# Altium schematic sheet XYAmpHammondFrontPnlRev1b.SchDoc(XYAmpHammondFrontPnlRev1b)
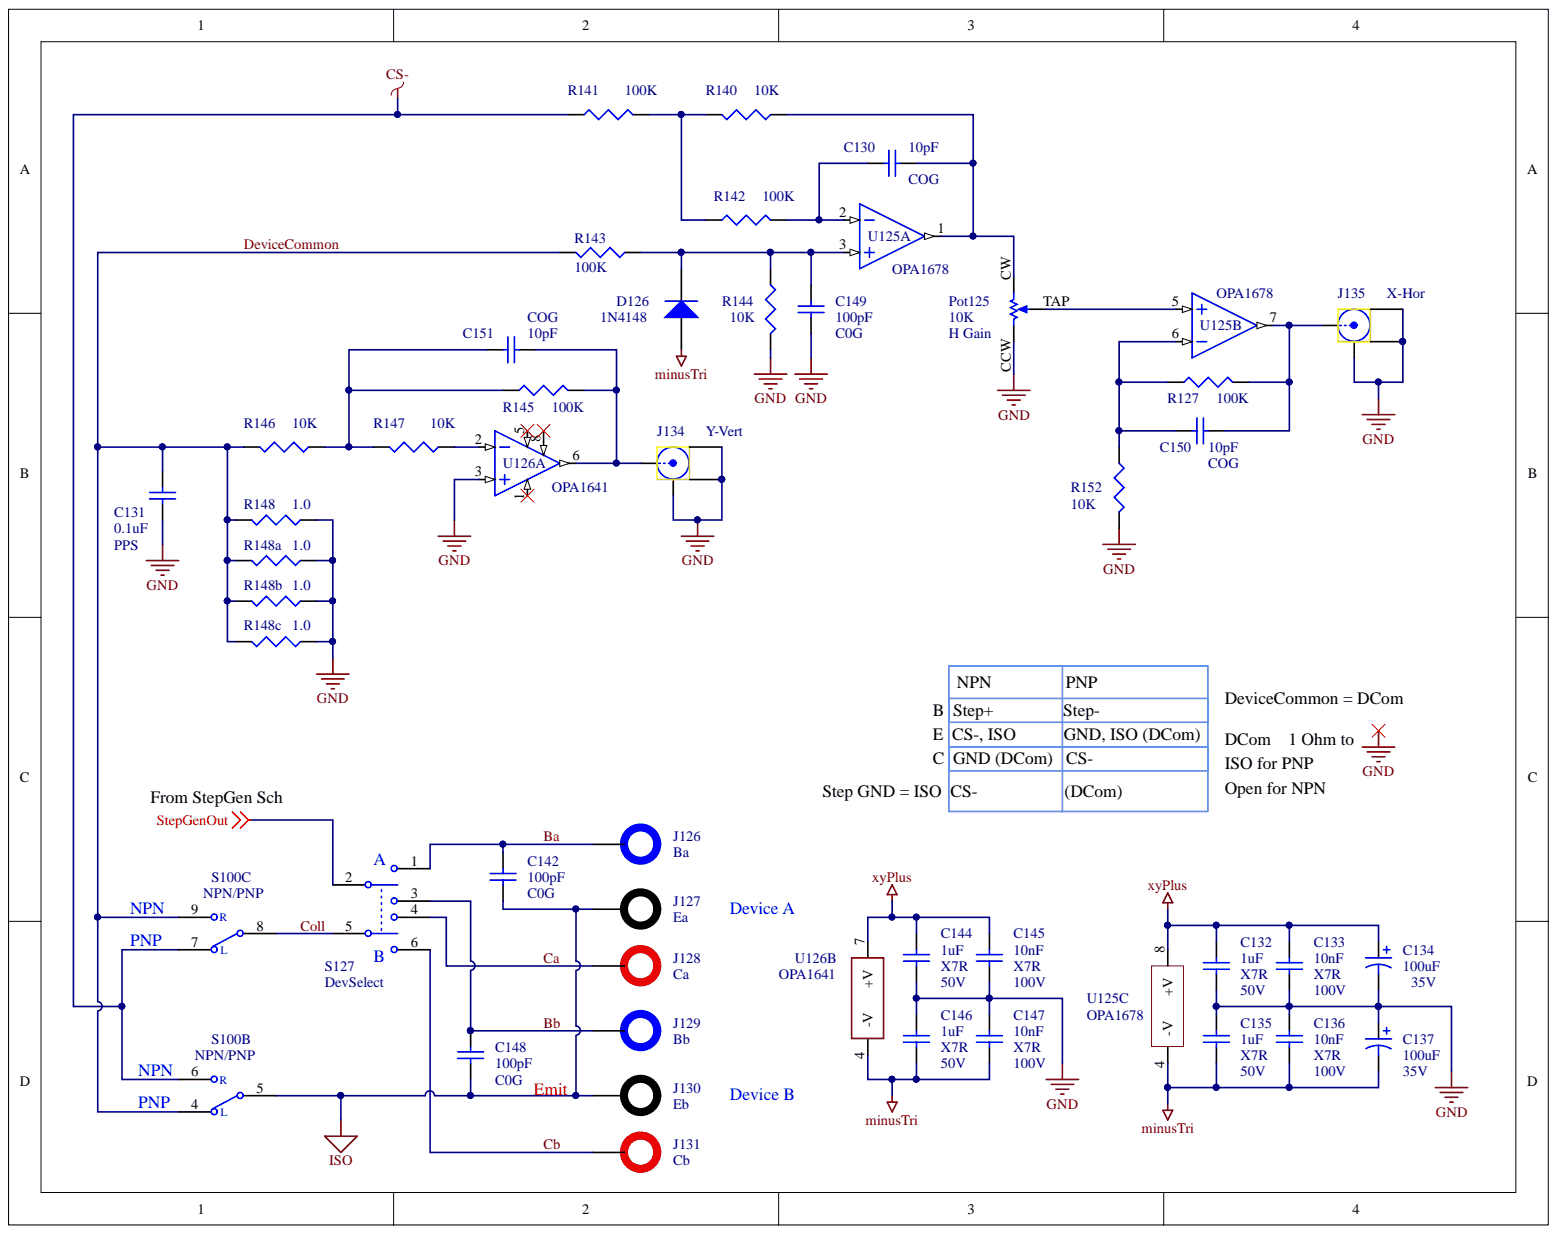
[diagram p0: XYAmpHammondFrontPnlRev1b.SchDoc]

## Parts
C130  value 10pF  Temperature Coefficient: COG  [CAPC3216M]  (hidden comment: Cap)
C131  value 0.1uF  Dielectric: PPS  [CAPC3225M]  (hidden comment: Cap)
C132  1uF  Voltage Rating (DC): 50V  Dielectric: X7R  [CAPC3216M]
C133  10nF  Voltage Rating (DC): 100V  Dielectric: X7R  [CAPC3216M]
C134  100uF  Voltage Rating: 35V  [CAPPR2.5-6.3X10]
C135  1uF  Voltage Rating (DC): 50V  Dielectric: X7R  [CAPC3216M]
C136  10nF  Voltage Rating (DC): 100V  Dielectric: X7R  [CAPC3216M]
C137  100uF  Voltage Rating: 35V  [CAPPR2.5-6.3X10]
C142  value 100pF  Temperature Coefficient: C0G  [CAPC3216M]  (hidden comment: Cap)
C144  1uF  Voltage Rating (DC): 50V  [CAPC3216M]
C145  10nF  Voltage Rating (DC): 100V  Dielectric: X7R  [CAPC3216M]
C146  1uF  Voltage Rating (DC): 50V  [CAPC3216M]
C147  10nF  Voltage Rating (DC): 100V  Dielectric: X7R  [CAPC3216M]
C148  value 100pF  Temperature Coefficient: C0G  [CAPC3216M]  (hidden comment: Cap)
C149  value 100pF  Temperature Coefficient: C0G  [CAPC3216M]  (hidden comment: Cap)
C150  value 10pF  Temperature Coefficient: COG  [CAPC3216M]  (hidden comment: Cap)
C151  value 10pF  Temperature Coefficient: COG  [CAPC3216M]  (hidden comment: Cap)
D126  1N4148  [SOD123]
J126  Ba  [Banana-Turet]
J127  Ea  [Banana-Turet]
J128  Ca  [Banana-Turet]
J129  Bb  [Banana-Turet]
J130  Eb  [Banana-Turet]
J131  Cb  [Banana-Turet]
J134  Y-Vert  [BNC-Vert]
J135  X-Hor  [BNC-Vert]
Pot125  H Gain  value 10K  [Off-PCB]
R127  100k  [RESC3216M]
R140  10K  [RESC3216M]
R141  100k  [RESC3216M]
R142  100k  [RESC3216M]
R143  100k  [RESC3216M]
R144  10K  [RESC3216M]
R145  100k  [RESC3216M]
R146  10K  [RESC3216M]
R147  10K  [RESC3216M]
R148  1  value 1.0  [RESC6432BM]
R148a  1  value 1.0  [RESC6432BM]
R148b  1  value 1.0  [RESC6432BM]
R148c  1  value 1.0  [RESC6432BM]
R152  10K  [RESC3216M]
S100  NPN/PNP  [EG2424]  (parts B,C)
S127  DevSelect  [EG2413]
U125  OPA1678  [SOIC127P600X168_HS-8N]  (parts A,B,C)
U126  OPA1641  [SOIC127P600X168_HS-8N]  (parts A,B)

## Nets
CS-: R141.1 S100.6(R) S100.7(L)
GND: C131.2 C132.2 C133.1 C134.2 C135.1 C136.2 C137.1 C144.2 C145.1 C146.1 C147.2 C149.1 J134.2 J134.MH0 J134.MH1 J135.2 J135.MH0 J135.MH1 Pot125.CCW R144.1 R148.1 R148a.1 R148b.1 R148c.1 R152.1 U126.3
ISO: C142.1 C148.1 J127.1 J130.1 S100.5
minusTri: C135.2 C136.1 C137.2 C146.2 C147.1 D126.1 U125.4(-V) U126.4(-V)
StepGenOut: S127.2
xyPlus: C132.1 C133.2 C134.1 C144.1 C145.2 U125.8(+V) U126.7(+V)
Ba: C142.2 J126.1 S127.1
Bb: C148.2 J129.1 S127.3
Ca: J128.1 S127.4
Cb: J131.1 S127.6(B)
Coll: S100.8 S127.5
DeviceCommon: C131.1 R143.2 R146.1 R148.2 R148a.2 R148b.2 R148c.2 S100.4(L) S100.9(R)
NetC130_1: C130.1 R142.2 U125.2
NetC130_2: C130.2 Pot125.CW R140.2 U125.1
NetC149_2: C149.2 D126.2 R143.1 R144.2 U125.3
NetC150_1: C150.1 R127.1 R152.2 U125.6
NetC150_2: C150.2 J135.1 R127.2 U125.7
NetC151_1: C151.1 R145.1 R146.2 R147.1
NetC151_2: C151.2 J134.1 R145.2 U126.6
NetPot125_TAP: Pot125.TAP U125.5
NetR140_1: R140.1 R141.2 R142.1
NetR147_2: R147.2 U126.2

Not connected: U126 1 5 8

## Library data of the parts
C130 C150 C151 = Cap: CAP CER 10PF 100V NP0 1206; Manufacturer Part Number: C1206C100J1GACTU; Manufacturer: Kemet; Tolerance: ±5%; Voltage - Rated: 100V; Thickness (Max): 0.035" (0.88mm); Supplier Part Number: 399-8124-1-ND; Supplier: Digi-Key; Size / Dimension: 0.126" L x 0.063" W (3.20mm x 1.60mm); Simulation: CAP; Signal Integrity: Cap; RoHS: RoHS Compliant; Packaging: Cut Tape (CT); Package / Case: 1206 (3216 Metric); Operating Temperature: -55°C ~ 125°C; Mounting Type: Surface Mount, MLCC; Library Name: Miscellaneous Devices.IntLib; Component Kind: Standard; Category: Capacitors; Capacitance: 10pF; Applications: General Purpose
C131 = Cap: CAP FILM 1UF 20% 16VDC 1210; Manufacturer Part Number: ECPU1C105MA5; Manufacturer: Panasonic; Voltage Rating (DC): 16V; Insulation Resistance: 300MR; Dissipation Factor: 1.5%; Width: 2.5mm; Voltage Rating: 16V; Voltage: 16V; Tolerance: 20%; Termination: SMD/SMT; Supplier Part Number: PCF1132CT-ND; Supplier: Digi-Key; Simulation: CAP; Signal Integrity: Cap; REACH SVHC: No SVHC; Radiation Hardening: No; Packaging: Tape and Reel; Package Quantity: 1; Number of Pins: 2; Mount: Surface Mount; Min Operating Temperature: -40°C; Max Operating Temperature: 85°C; Library Name: Miscellaneous Devices.IntLib; Length: 3.2mm; Lead Free: Lead Free; Height - Seated (Max): 0.063inch; Height: 1.4mm; Depth: 2.5mm; Contact Plating: Copper,Tin,Silver; Component Kind: Standard; Case Code (Metric): 3225; Case Code (Imperial): 1210; Case/Package: 1210; Capacitance: 1uF
C132 C135 C144 C146 = Cap: CAP CER 1UF 50V X7R 1206; Manufacturer Part Number: C1206C105K5RACTU; Manufacturer: KEMET; Tolerance: 10%; Dielectric Material: Ceramic; Width: 0.063inch; Voltage Rating: 50V; Voltage: 50V; Value: 1uF; Thickness: 0.043inch; Termination: SMD/SMT; Supplier Part Number: 399-8147-6-ND; Supplier: Digi-Key; Simulation: CAP; Signal Integrity: Cap; Series: C; REACH SVHC: No SVHC; Radiation Hardening: No; Packaging: Tape and Reel; Package Quantity: 1; Number of Pins: 2; Mount: Surface Mount; Min Operating Temperature: -55°C; Max Operating Temperature: 125°C; Library Name: Miscellaneous Devices.IntLib; Length: 3.2mm; Height: 1mm; Depth: 1.6mm; Construction: Flat; Component Kind: Standard; Case Code (Metric): 3216; Case Code (Imperial): 1206; Case/Package: 1206; Capacitance: 1uF
  C144 C146: Dielectric: X7R
C133 C136 C145 C147 = Cap: CAP CER 10NF 100V X7R 1206; Manufacturer Part Number: C1206C103K1RACTU; Manufacturer: KEMET; Tolerance: 10%; Dissipation Factor: 2.5%; Dielectric Material: Ceramic; Width: 0.063inch; Voltage Rating: 100V; Voltage: 100V; Value: 10nF; Thickness: 0.035inch; Termination: SMD/SMT; Supplier Part Number: 399-1236-6-ND; Supplier: Digi-Key; Simulation: CAP; Signal Integrity: Cap; Series: C; REACH SVHC: No SVHC; Radiation Hardening: No; Packaging: Tape and Reel; Package Quantity: 1; Number of Pins: 2; Mount: Surface Mount,PCB; Min Operating Temperature: -55°C; Max Operating Temperature: 125°C; Library Name: Miscellaneous Devices.IntLib; Length: 3.2mm; Height: 0.78mm; Depth: 1.6mm; Contact Plating: Tin,Matte; Construction: Flat; Component Kind: Standard; Case Code (Metric): 3216; Case Code (Imperial): 1206; Case/Package: 1206; Capacitance: 10nF
C134 C137 = Cap Pol1: CAP ALUM 100UF 20% 35V THRU HOLE; Manufacturer Part Number: 35YXJ100M6.3X11; Manufacturer: Rubycon; Ripple Current: 400mA; Polarity: Polar; Life (Hours): 7000Hour; Leakage Current: 3uA; Lead Diameter: 0.5mm; Impedance: 220mR; Voltage: 35V; Value: 100uF; Tolerance: 20%; Termination: Radial; Supplier Part Number: 1189-2260-ND; Supplier: Digi-Key; Simulation: CAP; Signal Integrity: Cap Pol; Radiation Hardening: No; Packaging: Bulk; Package Quantity: 3000; Mount: Through Hole; Min Operating Temperature: -40°C; Max Operating Temperature: 105°C; Library Name: Miscellaneous Devices.IntLib; Lead Pitch: 2.5mm; Height - Seated (Max): 0.492inch; Height: 11mm; Diameter: 6.3mm; Component Kind: Standard; Case/Package: Radial; Capacitance: 100uF
C142 C148 C149 = Cap: CAP CER 100PF 100V NP0 1206; Manufacturer Part Number: C1206C101J1GACTU; Manufacturer: Kemet; Tolerance: ±5%; Voltage - Rated: 100V; Thickness (Max): 0.035" (0.88mm); Supplier Part Number: 399-1206-1-ND; Supplier: Digi-Key; Size / Dimension: 0.126" L x 0.063" W (3.20mm x 1.60mm); Simulation: CAP; Signal Integrity: Cap; RoHS: RoHS Compliant; Packaging: Cut Tape (CT); Package / Case: 1206 (3216 Metric); Operating Temperature: -55°C ~ 125°C; Mounting Type: Surface Mount, MLCC; Library Name: Miscellaneous Devices.IntLib; Features: Low ESL; Component Kind: Standard; Category: Capacitors; Capacitance: 100pF; Applications: General Purpose
D126 = Diode 1N4148: DIODE GP 75V 150MA SOD123FL; Manufacturer Part Number: 1N4148WFL-G3-08; Manufacturer: Vishay Semiconductor Diodes Division; Voltage - Forward (Vf) (Max) @ If: 1.2V @ 100mA; Speed: Small Signal =< 200mA (Io), Any Speed; Reverse Recovery Time (trr): 4ns; Diode Type: Standard; Current - Reverse Leakage @ Vr: 5µA @ 75V; Voltage - DC Reverse (Vr) (Max): 75V; Value: 1N4148; Supplier Part Number: 1N4148WFL-G3-08GICT-ND; Supplier Device Package: SOD-123FL; Supplier: Digi-Key; Simulation: 1N4148; Signal Integrity: 1N4148; RoHS: RoHS Compliant; Published: 8-Jun-2000; Packaging: Cut Tape (CT); PackageReference: DO-35; PackageDescription: Diode, Axial; Body 3.8 x 1.9 mm (LxDia. max), Lead Dia. 0.53 mm (max); Package / Case: SOD-123F; Operating Temperature - Junction: 150°C (Max); Mounting Type: Surface Mount; Library Name: Miscellaneous Devices.IntLib; LatestRevisionNote: Re-released for DXP Platform.; LatestRevisionDate: 17-Jul-2002; DatasheetVersion: 1997; Current - Average Rectified (Io): 150mA; ComponentLink2URL: http://www.fairchildsemi.com/ds/1N%2F1N4148.pdf; ComponentLink2Description: Datasheet; ComponentLink1URL: http://www.fairchildsemi.com/; ComponentLink1Description: Manufacturer Link; Component Kind: Standard; Code_JEDEC: DO-204-AH; Category: Discrete Semiconductor Products; Publisher: Altium Limited
J126 J129 = Banana-BLUE: Conn Banana F 1 POS Solder Lug ST Panel Mount 1 Port; Manufacturer Part Number: 108-0910-001; Manufacturer: Bel Cinch; Wire/Cable Gauge: 12AWG; Voltage Rating (DC): 7kV; Value: Blue; Number of Contacts: 1; Max Current Rating: 15A; Insulation: Mating End Insulated; Gender: Female; Body Material: Nylon,Polyamide,PA66,Brass; Approvals: UL; Voltage Rating: 7kV; Termination: Solder; Supplier Part Number: J155-ND; Supplier: Digi-Key; Series: -; REACH SVHC: No SVHC; Radiation Hardening: No; Packaging: Bulk; Package Quantity: 25; Orientation: Straight; Mount: Panel; Min Operating Temperature: -55°C; Max Operating Temperature: 85°C; Library Name: MY_CONNECTORS.SchLib; Length: 0.75inch; Current Rating: 15A; Contact Plating: Nickel,Tin; Contact Material: Brass; Component Kind: Standard; Color: Blue
J127 J130 = Banana-BLACK: Conn Banana F 1 POS Solder Lug ST Panel Mount 1 Port; Manufacturer Part Number: 108-0903-001; Manufacturer: Bel Cinch; Wire/Cable Gauge: 12AWG; Voltage Rating (DC): 7kV; Value: Black; Number of Contacts: 1; Max Current Rating: 15A; Insulation: Mating End Insulated; Gender: Female; Body Material: Nylon,Polyamide,PA66,Brass; Approvals: UL; Voltage Rating: 7kV; Termination: Solder; Supplier Part Number: J152-ND; Supplier: Digi-Key; Series: -; REACH SVHC: No SVHC; Radiation Hardening: No; Packaging: Bulk; Package Quantity: 25; Orientation: Straight; Mount: Panel; Min Operating Temperature: -55°C; Max Operating Temperature: 85°C; Library Name: MY_CONNECTORS.SchLib; Length: 25.78mm; Insulation Material: Nylon; Current Rating: 15A; Contact Plating: Nickel,Tin; Contact Material: Brass; Component Kind: Standard; Color: Black
J128 J131 = Banana-RED: Conn Banana F 1 POS Solder Lug ST Panel Mount 1 Port; Manufacturer Part Number: 108-0902-001; Manufacturer: Bel Cinch; Wire/Cable Gauge: 12AWG; Voltage Rating (DC): 7kV; Value: Red; Number of Contacts: 1; Max Current Rating: 15A; Insulation: Mating End Insulated; Gender: Female; Body Material: Nylon,Polyamide,PA66,Brass; Approvals: UL; Voltage Rating: 7kV; Termination: Solder; Supplier Part Number: J151-ND; Supplier: Digi-Key; Series: -; REACH SVHC: No SVHC; Radiation Hardening: No; Packaging: Bulk; Package Quantity: 25; Orientation: Straight; Mount: Panel; Min Operating Temperature: -55°C; Max Operating Temperature: 85°C; Library Name: MY_CONNECTORS.SchLib; Length: 25.78mm; Insulation Material: Nylon; Current Rating: 15A; Contact Plating: Tin,Nickel; Contact Material: Brass; Component Kind: Standard; Color: Red
J134 J135 = BNC-Vert: CONN BNC JACK STR 50 OHM PCB; Manufacturer: TE Connectivity; Wire/Cable Type: Coaxial; Value: 1-1634505-0; Tail Length: 0.169inch; Sealable: No (Without); Polarity: Normal; Plating: Nickel; PCB Thickness: 0.126inch; PCB Mount Retention: With; PCB Mounting Orientation: Vertical; Number of Positions: 1; Max Frequency: 4GHz; Insulation Resistance: 5GR; Impedance: 50R; Gender: Female; Dielectric Material: Polyethylene; Connector Type: BNC,Jack; Body Material: Zinc; Termination: Solder; Supplier Part Number: A97558-ND; Supplier: Digi-Key; REACH SVHC: Unknown; Radiation Hardening: No; Packaging: Box; Package Quantity: 100; Orientation: Straight; Mount: Panel; Min Operating Temperature: -55°C; Max Operating Temperature: 85°C; Manufacturer Part Number: 1-1634505-0; Library Name: MY_CONNECTORS.SchLib; Length: 15.8mm; Insulation Material: Polyethylene; Housing Material: Polyester; Housing Color: Black; Frequency: 4GHz; Fastening Type: Bayonet; ELV: Compliant; Depth: 14.76mm; Contact Plating: Gold; Contact Material: Brass; Component Kind: Standard; Color: Black; Body Plating: Nickel
Pot125 = POT-Single: PNL MNT 10K OHM 0.125W; Manufacturer Part Number: RV16AF-10-15R1-B10K-3LA; Manufacturer: Alpha Taiwan; Supplier Part Number: 31JN501-F; Supplier: Mouser; Component Kind: Standard; Library Name: MY_POTS.SchLib
R127 R141-R143 R145 = Res3: RES 100K OHM 1% 1/4W 1206; Manufacturer Part Number: RMCF1206FT100K; Manufacturer: Stackpole Electronics; Temperature Coefficient: 100ppm/°C; Resistance: 100kR; Power Rating: 250mW; Width: 0.063inch; Value: 100K; Tolerance: 1%; Supplier Part Number: RMCF1206FT100KCT-ND; Supplier: Digi-Key; Simulation: RESISTOR; Signal Integrity: Res; Radiation Hardening: No; Packaging: Tape and Reel; Number of Terminations: 2; Mount: Surface Mount; Min Operating Temperature: -55°C; Military Standard: Not; Max Power Dissipation: 250mW; Max Operating Temperature: 155°C; Library Name: Miscellaneous Devices.IntLib; Length: 0.126inch; Lead Free: Lead Free; Height: 0.028inch; Features: Automotive AEC-Q200; Depth: 1.6mm; Contact Plating: Matte,Tin; Composition: Thick Film; Component Kind: Standard; Case Code (Metric): 3216; Case Code (Imperial): 1206; Case/Package: 1206
R140 R144 R146 R147 R152 = Res3: RES 10K OHM 1% 1/4W 1206; Manufacturer Part Number: RMCF1206FT10K0; Manufacturer: Stackpole Electronics; Voltage Rating (DC): 200V; Temperature Coefficient: 100ppm/°C; Resistance: 10kR; Power Rating: 250mW; Width: 0.063inch; Voltage Rating: 200V; Value: 10K; Tolerance: 1%; Termination: SMD/SMT; Supplier Part Number: RMCF1206FT10K0CT-ND; Supplier: Digi-Key; Simulation: RESISTOR; Signal Integrity: Res; REACH SVHC: No SVHC; Radiation Hardening: No; Packaging: Tape and Reel; Package Quantity: 1; Number of Terminations: 2; Number of Pins: 2; Mount: Surface Mount; Min Operating Temperature: -55°C; Military Standard: Not; Max Power Dissipation: 250mW; Max Operating Temperature: 155°C; Library Name: Miscellaneous Devices.IntLib; Length: 3.2mm; Lead Free: Lead Free; Height: 0.028inch; Features: Automotive AEC-Q200; Depth: 1.6mm; Contact Plating: Matte,Tin; Composition: Thick Film; Component Kind: Standard; Case Code (Metric): 3216; Case Code (Imperial): 1206; Case/Package: 1206
R148 R148a R148b R148c = Res3: RES 1` OHM 1% 1/4W 1206; Manufacturer Part Number: RMCF1206FT1R00; Manufacturer: Stackpole Electronics; Temperature Coefficient: 200ppm/°C; Resistance: 1R; Power Rating: 250mW; Width: 0.063inch; Tolerance: 1%; Supplier Part Number: RMCF1206FT1R00CT-ND; Supplier: Digi-Key; Simulation: RESISTOR; Signal Integrity: Res; Radiation Hardening: No; Packaging: Tape and Reel; Number of Terminations: 2; Mount: Surface Mount; Min Operating Temperature: -55°C; Military Standard: Not; Max Power Dissipation: 250mW; Max Operating Temperature: 155°C; Library Name: Miscellaneous Devices.IntLib; Length: 3.2mm; Lead Free: Lead Free; Height: 0.028inch; Features: Automotive AEC-Q200; Depth: 1.6mm; Contact Plating: Tin,Matte; Composition: Thick Film; Component Kind: Standard; Case Code (Metric): 3216; Case Code (Imperial): 1206; Case/Package: 1206
S100 = 3PDT: SWITCH TOGGLE 3PDT 5A 120V; Manufacturer Part Number: 1003P1T1B1M2QEH; Manufacturer: E-Switch; Voltage Rating (DC): 28V; Value: EG2424; Switch Function: On-On; Illumination Type: Non-Illuminated,Illuminated; Electrical Life: 40000Cycles; Actuator Type: Standard Round; Actuator Material: Brass,Chrome; Actuator Length: 10.41mm; Width: 16.5mm; Voltage Rating (AC): 120V; Throw Configuration: 3PDT; Termination: PC Pin; Supplier Part Number: EG2425-ND; Supplier: Digi-Key; Series: 100; Packaging: Bulk; Package Quantity: 1; Orientation: Vertical; Mount: Panel; Min Operating Temperature: -30°C; Max Operating Temperature: 85°C; Library Name: MY_SWITCH.SchLib; Length: 12.7mm; Lead Free: Lead Free; Current Rating: 5A; Contact Plating: Silver; Contact Material: Copper,Silver; Component Kind: Standard
S127 = SW-DPDT: SWITCH TOGGLE DPDT 5A 120V; Manufacturer Part Number: 100DP3T1B1M1QEH; Manufacturer: E-Switch; Voltage Rating (DC): 28V; Value: EG2413; Switch Function: On-Off-On; Max Voltage Rating (AC): 250V; Illumination Type: Illuminated,Non-Illuminated; Electrical Life: 40000Cycles; Actuator Type: Standard Round; Actuator Material: Brass,Chrome; Actuator Length: 10.41mm; Voltage Rating (AC): 120V; Throw Configuration: DPDT; Termination: Solder; Supplier Part Number: EG2413-ND; Supplier: Digi-Key; Packaging: Bulk; Package Quantity: 500; Orientation: Straight; Mount: Panel; Min Operating Temperature: -30°C; Max Voltage Rating (DC): 28V; Max Operating Temperature: 85°C; Library Name: My_Miscellaneous Devices.IntLib; Lead Free: Lead Free; Current Rating: 5A; Contact Plating: Silver; Contact Material: Silver; Component Kind: Standard
U125 = Dual OP Amp separte supplies: IC AUDIO AMP 2 CIRCUIT 8VSSOP; Manufacturer Part Number: OPA1678IDGKT; Manufacturer: Texas Instruments; Slew Rate: 9V/µs; Operating Supply Current: 2mA; Number of Circuits: 2; Input Offset Voltage (Vos): 500uV; Input Bias Current: 10pA; Gain Bandwidth Product: 16MHz; Value: OPA1678; Supplier Part Number: 296-46561-2-ND; Supplier: Digi-Key; Packaging: Tape and Reel; Number of Channels: 2; Mount: Surface Mount; Min Operating Temperature: -40°C; Max Operating Temperature: 85°C; Library Name: My_OpAmps.SchLib; Component Kind: Standard; Case/Package: VSSOP
U126 = Single OP Amp separate supply.: IC OPAMP AUDIO 11MHZ RRO 8SOIC; Manufacturer Part Number: OPA1641AIDR; Manufacturer: Texas Instruments; Voltage Gain: 134dB; Value: OPA1641AIIDR; Slew Rate: 20V/µs; Power Supply Rejection Ratio (PSRR): 137.08dB; Output Current per Channel: 36mA; Operating Supply Current: 1.8mA; Number of Elements: 1; Min Supply Voltage: 4.5V; Min Dual Supply Voltage: 2.25V; Max Supply Voltage: 36V; Max Dual Supply Voltage: 18V; Input Offset Voltage (Vos): 3.5mV; Input Bias Current: 2pA; Common Mode Rejection Ratio: 120dB; Unity Gain Bandwidth Product: 11MHz; Supplier Part Number: 296-48624-1-ND; Supplier: Digi-Key; Radiation Hardening: No; Packaging: Tape and Reel; Package Quantity: 2500; Number of Pins: 8; Number of Circuits: 1; Number of Channels: 1; Nominal Supply Current: 1.8mA; Nominal Gain Bandwidth Product: 11MHz; Min Operating Temperature: -40°C; Max Operating Temperature: 85°C; Library Name: My_OpAmps.SchLib; Lead Free: Lead Free; Gain Bandwidth Product: 11MHz; Component Kind: Standard; Case/Package: D
